# Altium schematic sheet DDS_Out.SchDoc(DDS_Out)
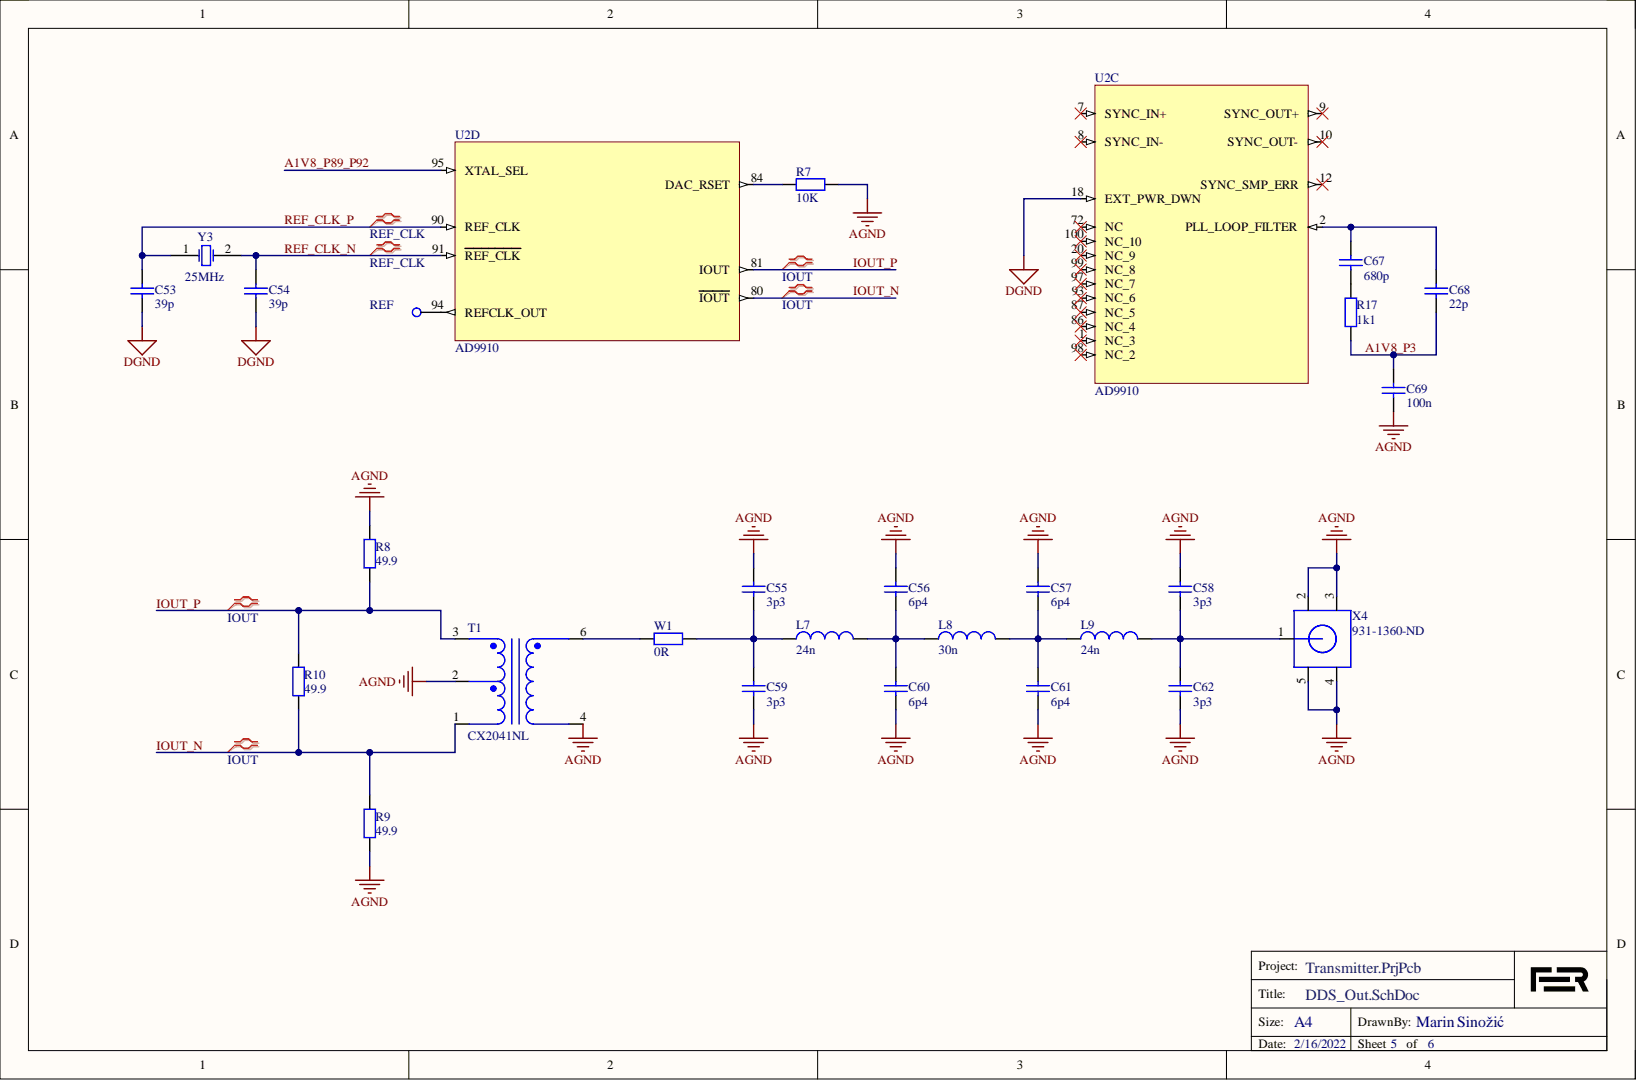
[diagram p0: DDS_Out.SchDoc]

## Parts
C53  39p  [0603]
C54  39p  [0603]
C55  3p3  [0603]
C56  6p4  [0603]
C57  6p4  [0603]
C58  3p3  [0603]
C59  3p3  [0603]
C60  6p4  [0603]
C61  6p4  [0603]
C62  3p3  [0603]
C67  680p  [0603]
C68  22p  [0603]
C69  100n  [0603]
L7  24n  [0603]
L8  30n  [0603]
L9  24n  [0603]
R7  10K  [0603]
R8  49.9  [0805]
R9  49.9  [0805]
R10  49.9  [0805]
R17  Resistance: 1k1  [0603]  (hidden comment: TBD)
REF  Test point 1mm  [TP]  (value not drawn)  (no BOM)
T1  CX2041NL  [RF_TRANSF]
U2  AD9910  [TSQFP50P1600X1600X105_HS-101N]  (parts C,D)
W1  0R  [0603]
X4  931-1360-ND  [SMA]
Y3  25MHz  [XTAL_ECS-196-S-23A-TR]

## Nets
AGND: C55.2 C56.2 C57.2 C58.2 C59.1 C60.1 C61.1 C62.1 C69.1 R7.1 R8.1 R9.2 T1.2 T1.4 X4.2 X4.3 X4.4 X4.5
DGND: C53.1 C54.1 U2.18(EXT_PWR_DWN)
A1V8_P3: C68.1 C69.2 R17.2
A1V8_P89_P92: U2.95(XTAL_SEL)
IOUT_N: R9.1 R10.2 T1.1 U2.80(IOUT)
IOUT_P: R8.2 R10.1 T1.3 U2.81(IOUT)
REF_CLK_N: C54.2 U2.91(REF_CLK) Y3.2
REF_CLK_P: C53.2 U2.90(REF_CLK) Y3.1
NetC55_1: C55.1 C59.2 L7.1 W1.2
NetC56_1: C56.1 C60.2 L7.2 L8.1
NetC57_1: C57.1 C61.2 L8.2 L9.1
NetC58_1: C58.1 C62.2 L9.2 X4.1
NetC67_1: C67.1 R17.1
NetC67_2: C67.2 C68.2 U2.2(PLL_LOOP_FILTER)
NetR7_2: R7.2 U2.84(DAC_RSET)
NetREF_1: REF.1 U2.94(REFCLK_OUT)
NetT1_6: T1.6 W1.1

Not connected: U2 1(NC_3) 7(SYNC_IN+) 8(SYNC_IN-) 9(SYNC_OUT+) 10(SYNC_OUT-) 12(SYNC_SMP_ERR) 20(NC_9) 72(NC) 86(NC_4) 87(NC_5) 93(NC_6) 97(NC_7) 98(NC_2) 99(NC_8) 100(NC_10)

## Library data of the parts
C53 C54 = Cap: CAP CER 39PF 50V C0G/NP0 0603; Manufacturer Part Number: 720-VJ0603A390GXACW1BCCT-ND; Component Kind: Standard; LatestRevisionDate: 17-Jul-2002; LatestRevisionNote: Re-released for DXP Platform.; Library Name: Miscellaneous Devices.IntLib; PackageDescription: Radial Cap, Thru-Hole; 2 Leads; 0.3 in Pin Spacing; PackageReference: RAD-0.3; Price: 0.15; Published: 8-Jun-2000; Signal Integrity: Cap; Simulation: CAP; Supplier 1: Digi-Key; Value: 39p; Publisher: Altium Limited
C55 C58 C59 C62 = C_0402_3.3pF: CAP CER 3.3PF 50V C0G/NPO 0603; Manufacturer: KEMET; Manufacturer Part Number: 311-3832-1-ND; Dielectric: C0G; Dielectric Material: Ceramic; Dissipation Factor: 0.1%; Temperature Coefficient: 30ppm/°C; Tolerance: 5%; Capacitance: 3.3pF; Case Code (Imperial): 0402; Case Code (Metric): 1005; Case/Package: 0402; Category: Ceramic Capacitors; Component Kind: Standard; ComponentLink1Description: https://datasheet.ciiva.com/144/docfinderpartnumber-c0402c339c5gactu-144736.pdf?src-supplier=Digi-Key; ComponentLink1URL: https://datasheet.ciiva.com/144/docfinderpartnumber-c0402c339c5gactu-144736.pdf?src-supplier=Digi-Key; ComponentLink2Description: https://datasheet.ciiva.com/26893/getdatasheetpartid-461459-26893979.pdf?src-supplier=Verical; ComponentLink2URL: https://datasheet.ciiva.com/26893/getdatasheetpartid-461459-26893979.pdf?src-supplier=Verical; ComponentLink3Description: https://datasheet.ciiva.com/11786/0900766b8116eaf8-11786284.pdf?src-supplier=RSComponents; ComponentLink3URL: https://datasheet.ciiva.com/11786/0900766b8116eaf8-11786284.pdf?src-supplier=RSComponents; ComponentLink4Description: https://datasheet.ciiva.com/7747/40889-7747531.pdf?src-supplier=Element14; ComponentLink4URL: https://datasheet.ciiva.com/7747/40889-7747531.pdf?src-supplier=Element14; ComponentLink5Description: https://datasheet.ciiva.com/14598/70095383-14598380.pdf?src-supplier=Allied+Electronics; ComponentLink5URL: https://datasheet.ciiva.com/14598/70095383-14598380.pdf?src-supplier=Allied+Electronics; ComponentLink6Description: https://datasheet.ciiva.com/22677/1372242-22677021.pdf?src-supplier=Element14; ComponentLink6URL: https://datasheet.ciiva.com/22677/1372242-22677021.pdf?src-supplier=Element14; ComponentLink7Description: https://datasheet.ciiva.com/5413/kem-c1003-c0g-smd-5413903.pdf?src-supplier=Mouser; ComponentLink7URL: https://datasheet.ciiva.com/5413/kem-c1003-c0g-smd-5413903.pdf?src-supplier=Mouser; ComponentLink8Description: https://datasheet.ciiva.com/14600/70095383-14600607.pdf?src-supplier=Allied+Electronics; ComponentLink8URL: https://datasheet.ciiva.com/14600/70095383-14600607.pdf?src-supplier=Allied+Electronics; ComponentLink9Description: https://datasheet.ciiva.com/22693/40889-22693919.pdf?src-supplier=Element14; ComponentLink9URL: https://datasheet.ciiva.com/22693/40889-22693919.pdf?src-supplier=Element14; Depth: 0.5mm; Height: 0.5mm; Lead Free: Lead Free; Lead Pitch: 0.3mm; Length: 1mm; Library Name: Tx_board.SchLib; Max Operating Temperature: 125°C; Min Operating Temperature: -55°C; Mount: Surface Mount,PCB; Number of Pins: 2; Package Quantity: 1; Packaging: Tape and Reel; Price: 0.11; Pricing 1: 1=0.10000, 10=0.07000, 100=0.03100, 500=0.02214, 1000=0.01739, 2500=0.01581, 5000=0.01455 (USD); Radiation Hardening: No; REACH SVHC: No SVHC; RoHS: Yes; Stock 1: 134170; Supplier 1: Digi-Key; Supplier Part Number 1: 399-1007-1-ND; Termination: SMD/SMT; Thickness: 0.022inch; Value: 3p3; Voltage: 50V; Voltage Rating: 50V; Voltage Rating (DC): 50V; Width: 0.02inch
C56 C57 C60 C61 C67-C69 = C_0402_6.8pF: CAP CER RF 6.4PF 50V +/-0.1 PF C; Manufacturer: Murata; Manufacturer Part Number: 399-16225-1-ND; Dielectric: C0G; Material: Ceramic; Case Code (Imperial): 0402; Case Code (Metric): 1005; Case/Package: 0402; Category: Ceramic Capacitors; Component Kind: Standard; ComponentLink1Description: https://datasheet.ciiva.com/6271/grm1555c1h6r8da01-01-6271041.pdf?src-supplier=Digi-Key; ComponentLink1URL: https://datasheet.ciiva.com/6271/grm1555c1h6r8da01-01-6271041.pdf?src-supplier=Digi-Key; ComponentLink2Description: https://datasheet.ciiva.com/11611/product-11611597.pdf?src-supplier=Avnet; ComponentLink2URL: https://datasheet.ciiva.com/11611/product-11611597.pdf?src-supplier=Avnet; ComponentLink3Description: https://datasheet.ciiva.com/18184/getdatasheetpartid-507743-18184478.pdf?src-supplier=Verical; ComponentLink3URL: https://datasheet.ciiva.com/18184/getdatasheetpartid-507743-18184478.pdf?src-supplier=Verical; ComponentLink4Description: https://datasheet.ciiva.com/29199/453990-da-01-en-vs-kondensator-0402-29199874.pdf?src-supplier=Conrad; ComponentLink4URL: https://datasheet.ciiva.com/29199/453990-da-01-en-vs-kondensator-0402-29199874.pdf?src-supplier=Conrad; ComponentLink5Description: https://datasheet.ciiva.com/6271/partnumbering-e-01-6271045.pdf?src-supplier=Digi-Key; ComponentLink5URL: https://datasheet.ciiva.com/6271/partnumbering-e-01-6271045.pdf?src-supplier=Digi-Key; ComponentLink6Description: https://datasheet.ciiva.com/6271/partnumbering-e-01-6271037.pdf?src-supplier=Digi-Key; ComponentLink6URL: https://datasheet.ciiva.com/6271/partnumbering-e-01-6271037.pdf?src-supplier=Digi-Key; Depth: 0.5mm; Height: 0.5mm; Lead Free: Lead Free; Length: 1mm; Library Name: Tx_board.SchLib; Max Operating Temperature: 125°C; Min Operating Temperature: -55°C; Mount: Surface Mount; Package Quantity: 1; Packaging: Tape and Reel; Price: 0.30; Pricing 1: 1=0.10000, 10=0.03900, 100=0.01740, 500=0.01240, 1000=0.00974, 2500=0.00886, 5000=0.00815 (USD); Radiation Hardening: No; REACH SVHC: No SVHC; RoHS: Yes; Stock 1: 1379879; Supplier 1: Digi-Key; Supplier Part Number 1: 490-5950-1-ND; Termination: SMD/SMT; Thickness: 0.022inch; Voltage: 50V; Voltage Rating: 50V; Voltage Rating (DC): 50V; Width: 0.02inch
  C56 C57 C60 C61: Capacitance: 6.4pF; Value: 6p4
  C67: Capacitance: 680p; Value: 680p
  C68: Capacitance: 6.4pF; Value: 22p
  C69: Capacitance: 6.4pF; Value: 100n
L7 L9 = L_0402_24nH: FIXED IND 24NH 500MA 210MOHM SMD; Manufacturer: Coilcraft; Manufacturer Part Number: 490-6877-1-ND; Core Material: Ceramic; Current Rating: 400mA; DC Resistance (DCR): 300mR; Inductance Tolerance: 2%; Q Factor: 50; Self Resonant Frequency: 2.7GHz; Test Frequency: 250MHz; Case Code (Imperial): 0402; Case Code (Metric): 1005; Case/Package: 0402; Category: Fixed Inductors; Component Kind: Standard; ComponentLink1Description: https://datasheet.ciiva.com/22708/1681871-22708549.pdf?src-supplier=Element14; ComponentLink1URL: https://datasheet.ciiva.com/22708/1681871-22708549.pdf?src-supplier=Element14; ComponentLink2Description: https://datasheet.ciiva.com/22621/1681871-22621870.pdf?src-supplier=Element14; ComponentLink2URL: https://datasheet.ciiva.com/22621/1681871-22621870.pdf?src-supplier=Element14; Height: 0.66mm; Inductance: 24nH; Lead Free: Contains Lead; Length: 1.19mm; Library Name: Tx_board.SchLib; Max DC Current: 400mA; Max Operating Temperature: 125°C; Min Operating Temperature: -40°C; Packaging: Cut Tape; Price: 0.18; Pricing 1: 1=1.78000, 10=1.56000, 50=1.26000, 100=1.16000, 200=1.10000, 500=0.69600 (USD); REACH SVHC: No SVHC; RoHS: Yes; Stock 1: 2009; Supplier 1: Digi-Key; Supplier Part Number 1: 994-0402CS-24NXGLU; Termination: SMD/SMT; Tolerance: 2%; Value: 24n; Weight: 2.3E-05oz; Width: 0.64mm
L8 = L_0402_30nH: FIXED IND 30NH 600MA 144MOHM SMD; Manufacturer: Coilcraft; Manufacturer Part Number: 490-15816-1-ND; Core Material: Ceramic; DC Resistance (DCR): 300mR; Max DC Current: 400mA; Q Factor: 39; Self Resonant Frequency: 2.35GHz; Test Frequency: 250MHz; Tolerance: 2%; Case/Package: 0402; Category: Fixed Inductors; Component Kind: Standard; ComponentLink1Description: http://www.mouser.com/ds/2/597/0402cs-253326.pdf?src-supplier=Mouser; ComponentLink1URL: http://www.mouser.com/ds/2/597/0402cs-253326.pdf?src-supplier=Mouser; Height: 0.66mm; Inductance: 30nH; Lead Free: Contains Lead; Length: 1.19mm; Library Name: Tx_board.SchLib; Max Operating Temperature: 125°C; Min Operating Temperature: -40°C; Packaging: Tape and Reel; Price: 0.25; Pricing 1: 1=1.82000, 10=1.59000, 50=1.29000, 100=1.18000, 200=1.12000, 500=0.71000 (USD); RoHS: Yes; Stock 1: 2709; Supplier 1: Digi-Key; Supplier Part Number 1: 994-0402CS-30NXGLW; Termination: SMD/SMT; Value: 30n; Weight: 2.3E-05oz; Width: 0.64mm
R7 = Res2: RES SMD 10K OHM 0.5% 1/5W 0603; Manufacturer Part Number: P20397CT-ND; Component Kind: Standard; LatestRevisionDate: 17-Jul-2002; LatestRevisionNote: Re-released for DXP Platform.; Library Name: Miscellaneous Devices.IntLib; PackageDescription: Axial Device, Thru-Hole; 2 Leads; 0.4 in Pin Spacing; PackageReference: AXIAL-0.4; Price: 0.16; Published: 8-Jun-2000; Signal Integrity: Res; Simulation: RESISTOR; Supplier 1: Digi-Key; Value: 10K; Publisher: Altium Limited
R8-R10 = Res2: RES SMD 49.9 OHM 0.1% 1/10W 0603; Manufacturer Part Number: P49.9DBCT-ND; Component Kind: Standard; LatestRevisionDate: 17-Jul-2002; LatestRevisionNote: Re-released for DXP Platform.; Library Name: Miscellaneous Devices.IntLib; PackageDescription: Axial Device, Thru-Hole; 2 Leads; 0.4 in Pin Spacing; PackageReference: AXIAL-0.4; Price: 0.32; Published: 8-Jun-2000; Signal Integrity: Res; Simulation: RESISTOR; Supplier 1: Digi-Key; Value: 49.9; Publisher: Altium Limited
R17 W1 = R_0603_0ohm: RES SMD 0 OHM JUMPER 1/3W 0603; Manufacturer: Panasonic; Manufacturer Part Number: 541-0.0SBCT-ND; Max Power Dissipation: 100mW; Temperature Coefficient: 600ppm/°C; Tolerance: 0%; Voltage Rating: 75V; Voltage Rating (DC): 50V; Case Code (Imperial): 0603; Case Code (Metric): 1608; Case/Package: 0603; Category: Chip SMD Resistors; Component Kind: Standard; ComponentLink1Description: https://datasheet.ciiva.com/27055/1935748-27055113.pdf?src-supplier=Jameco; ComponentLink1URL: https://datasheet.ciiva.com/27055/1935748-27055113.pdf?src-supplier=Jameco; ComponentLink2Description: https://datasheet.ciiva.com/11808/0900766b80f806dd-11808207.pdf?src-supplier=RSComponents; ComponentLink2URL: https://datasheet.ciiva.com/11808/0900766b80f806dd-11808207.pdf?src-supplier=RSComponents; ComponentLink3Description: https://datasheet.ciiva.com/48532/aoa0000ce1-48532165.pdf?src-supplier=Avnet; ComponentLink3URL: https://datasheet.ciiva.com/48532/aoa0000ce1-48532165.pdf?src-supplier=Avnet; ComponentLink4Description: https://datasheet.ciiva.com/43460/1678796-43460647.pdf?src-supplier=Farnell; ComponentLink4URL: https://datasheet.ciiva.com/43460/1678796-43460647.pdf?src-supplier=Farnell; ComponentLink5Description: https://datasheet.ciiva.com/28695/1678796-28695833.pdf?src-supplier=Element14; ComponentLink5URL: https://datasheet.ciiva.com/28695/1678796-28695833.pdf?src-supplier=Element14; ComponentLink6Description: https://datasheet.ciiva.com/5959/1678796-5959707.pdf?src-supplier=Farnell; ComponentLink6URL: https://datasheet.ciiva.com/5959/1678796-5959707.pdf?src-supplier=Farnell; ComponentLink7Description: https://datasheet.ciiva.com/16834/aoa0000ce1-16834828.pdf?src-supplier=Future+Electronics; ComponentLink7URL: https://datasheet.ciiva.com/16834/aoa0000ce1-16834828.pdf?src-supplier=Future+Electronics; ComponentLink8Description: https://datasheet.ciiva.com/17215/aoa0000ce1-17215388.pdf?src-supplier=Avnet+Europe; ComponentLink8URL: https://datasheet.ciiva.com/17215/aoa0000ce1-17215388.pdf?src-supplier=Avnet+Europe; ComponentLink9Description: https://datasheet.ciiva.com/46377/1678796-46377868.pdf?src-supplier=Element14; ComponentLink9URL: https://datasheet.ciiva.com/46377/1678796-46377868.pdf?src-supplier=Element14; ComponentLink10Description: https://datasheet.ciiva.com/30199/4aoa0000ce1-30199822.pdf?src-supplier=Onlinecomponents.com; ComponentLink10URL: https://datasheet.ciiva.com/30199/4aoa0000ce1-30199822.pdf?src-supplier=Onlinecomponents.com; ComponentLink11Description: https://datasheet.ciiva.com/9198/aoa0000ce1-9198975.pdf?src-supplier=Avnet; ComponentLink11URL: https://datasheet.ciiva.com/9198/aoa0000ce1-9198975.pdf?src-supplier=Avnet; ComponentLink12Description: https://datasheet.ciiva.com/16758/70255313-16758527.pdf?src-supplier=Allied+Electronics; ComponentLink12URL: https://datasheet.ciiva.com/16758/70255313-16758527.pdf?src-supplier=Allied+Electronics; Composition: Thick Film; Contact Plating: Tin; Depth: 0.8mm; Features: Automotive AEC-Q200; Height: 0.45mm; Lead Free: Lead Free; Length: 1.6mm; Library Name: Tx_board.SchLib; Max Operating Temperature: 155°C; Military Standard: Not; Min Operating Temperature: -55°C; Mount: Surface Mount; Number of Terminations: 2; Package Quantity: 1; Packaging: Tape and Reel; Power Rating: 100mW; Price: 0.14; Pricing 1: 1=0.10000, 10=0.03900, 25=0.02840, 50=0.02160, 100=0.01600, 250=0.01220, 500=0.00976, 1000=0.00718 (USD); Radiation Hardening: No; REACH SVHC: No SVHC; RoHS: Yes; Stock 1: 13282495; Supplier 1: Digi-Key; Supplier Part Number 1: P0.0GDKR-ND; Termination: SMD/SMT; Width: 0.031inch
  W1: Resistance: 0R
REF = TP_SMD_c100: Test_Point; Database Table Name: Mechanical; Library Name: RA.DbLib; Part Number: TP_SMD_c100; RA_SN: MECH-0067; Value: Test point 1mm
T1 = RF_TRANSF: BALUN 50KHZ-450MHZ 75/75 5SMD; Manufacturer: Pulse; Manufacturer Part Number: 553-3187-ND; Frequency: 1.5GHz; Turns Ratio: 1:1; Case/Package: SMD/SMT; Category: Uncategorized; Component Kind: Standard; ComponentLink1Description: https://datasheet.ciiva.com/26944/getdatasheetpartid-790077-26944021.pdf?src-supplier=Verical; ComponentLink1URL: https://datasheet.ciiva.com/26944/getdatasheetpartid-790077-26944021.pdf?src-supplier=Verical; Library Name: Tx_board.SchLib; Max Operating Temperature: 85°C; Min Operating Temperature: -40°C; Mount: Surface Mount; Packaging: Tray; Price: 2.91; Pricing 1: 1=2.70000, 10=2.16000, 25=1.75520, 50=1.71000, 100=1.66500, 250=1.62000, 500=1.60650, 1000=1.42200, 5000=1.30500 (USD); RoHS: Yes; Stock 1: 1966; Supplier 1: Digi-Key; Supplier Part Number 1: 553-3187-ND
U2 = AD9910BSVZ: ANALOG DEVICES - AD9910BSVZ - Direct Digital Synthesizer, 1 GSPS. 14 Bit, 3.3 V,; Manufacturer Part Number: 505-AD9910BSVZ-ND; Component Kind: Standard; Library Name: Transmitter.SchLib; Price: 72.64; Supplier 1: Digi-Key
X4 = SMA_JACK: CONN RP-SMA JACK R/A 50 OHM PCB; Manufacturer: Taoglas; Body Material: Brass; Body Plating: Gold; Connector Type: SMA,Male Pin; Dielectric Material: PTFE; Frequency: 6GHz; Impedance: 50R; Voltage Rating: 500V; Case/Package: SMA; Category: RF / Coaxial Connectors; Component Kind: Standard; ComponentLink1Description: http://www.taoglas.com/wp-content/uploads/2016/01/PCB.RPSMAFRA.HT_.pdf?src-supplier=Digi-Key; ComponentLink1URL: http://www.taoglas.com/wp-content/uploads/2016/01/PCB.RPSMAFRA.HT_.pdf?src-supplier=Digi-Key; ComponentLink2Description: http://taoglas.com/images/product_images/original_images/PCB.RPSMAFRA.HT.pdf?src-supplier=Avnet; ComponentLink2URL: http://taoglas.com/images/product_images/original_images/PCB.RPSMAFRA.HT.pdf?src-supplier=Avnet; Contact Material: Brass; Contact Plating: Gold; Fastening Type: Threaded; Housing Color: Gold; Library Name: Tx_board.SchLib; Manufacturer Part Number: 931-1360-ND; Max Frequency: 6GHz; Mount: Through Hole,Surface Mount; Orientation: Right Angle; Package Quantity: 500; Packaging: Tray; Price: 3.10; Pricing 1: 1=3.10000, 100=2.84000, 500=2.58000, 1000=2.28760 (USD); RoHS: Yes; Stock 1: 1777; Supplier 1: Digi-Key; Supplier Part Number 1: 931-1360-ND; Termination: Solder
Y3 = XTAL: Crystal 25.0MHZ 20PF SMD; Manufacturer Part Number: 50-ECS-250-20-23A-EN-TRCT-ND; Component Kind: Standard; LatestRevisionDate: 17-Jul-2002; LatestRevisionNote: Re-released for DXP Platform.; Library Name: Miscellaneous Devices.IntLib; PackageDescription: Crystal, Thru-Hole; 2 Leads; Body 3.1 x 8.2 mm (Dia.xH); PackageReference: R38; Price: 1.01; Published: 8-Jun-2000; Simulation: XTAL; Supplier 1: Digi-Key; Publisher: Altium Limited
